# Altium schematic sheet MARK1_EDU_PMOD.SchDoc
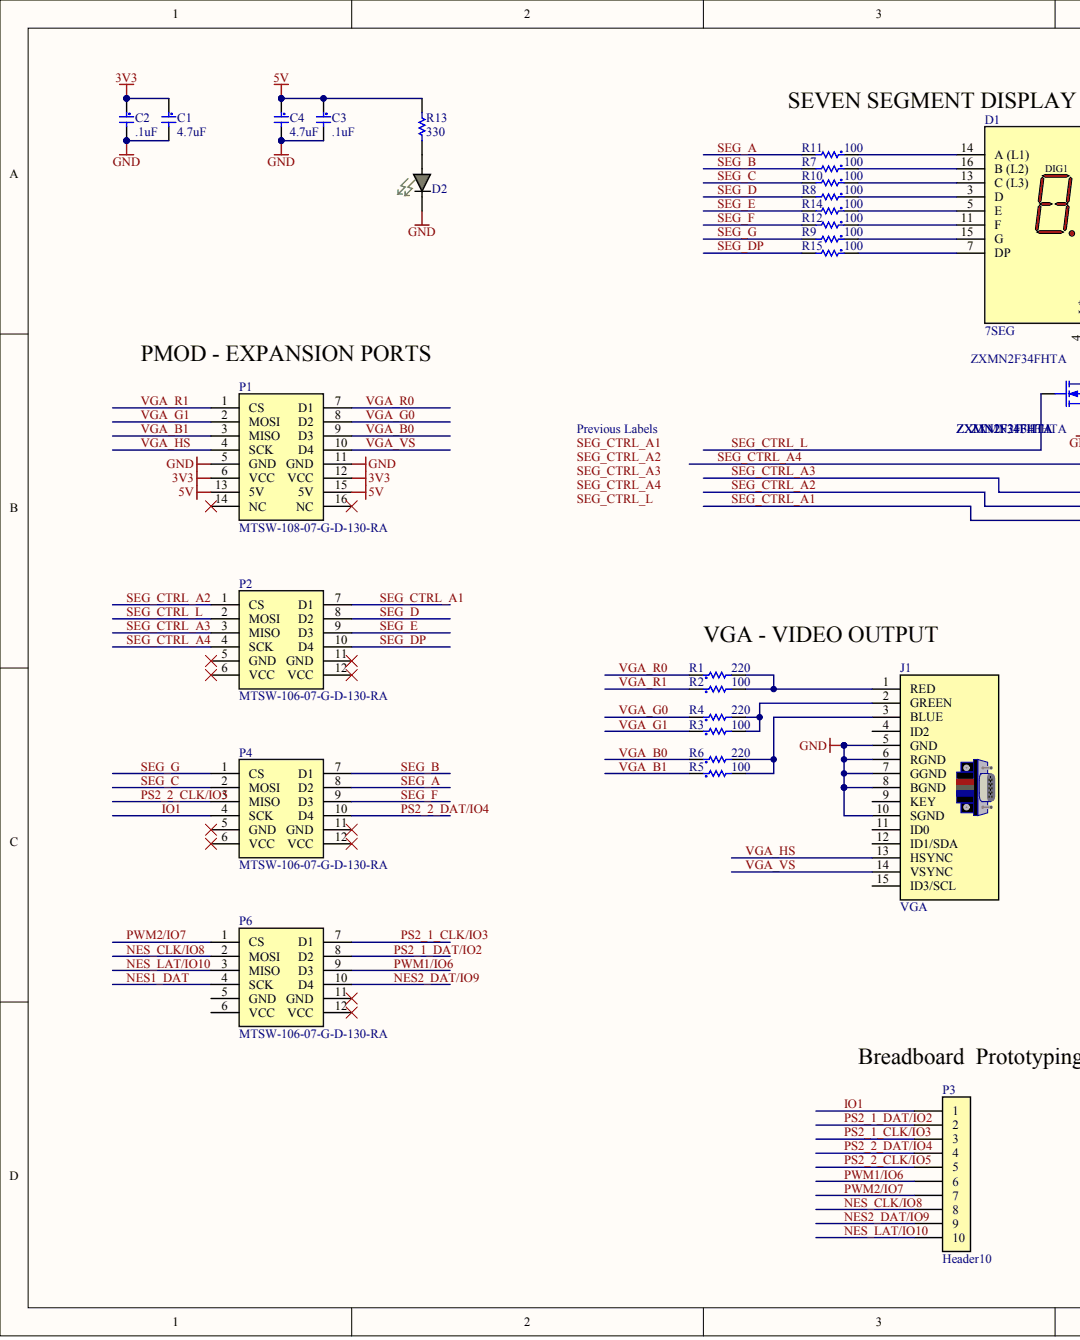
[diagram p0: MARK1_EDU_PMOD.SchDoc, left]
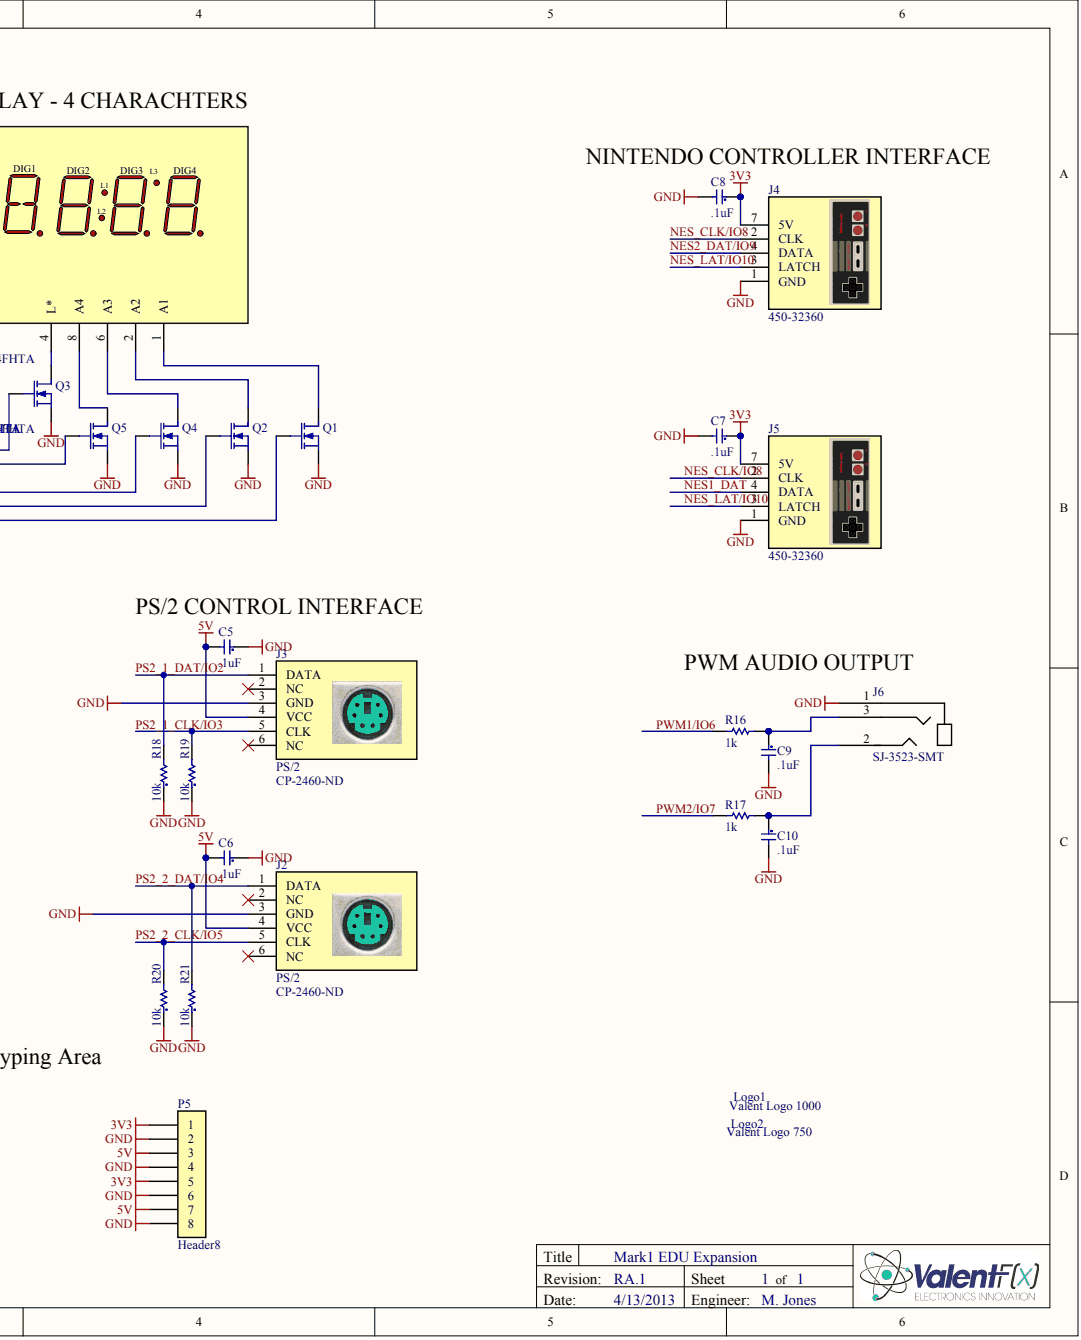
[diagram p1: MARK1_EDU_PMOD.SchDoc, right]

## Parts
C1  4.7uF
C2  .1uF
C3  .1uF
C4  4.7uF
C5  .1uF
C6  .1uF
C7  .1uF
C8  .1uF
C9  .1uF
C10  .1uF
D1  7SEG
D2  LED 1.6X0.8MM 570NM GRN CLR SMD  (value not drawn)
J1  VGA
J2  Supplier Part Number 1: CP-2460-ND  (hidden comment: PS/2)
J3  Supplier Part Number 1: CP-2460-ND  (hidden comment: PS/2)
J4  450-32360
J5  450-32360
J6  SJ-3523-SMT
Logo1
Logo2
P1  MTSW-108-07-G-D-130-RA
P2  MTSW-106-07-G-D-130-RA
P3  Header10
P4  MTSW-106-07-G-D-130-RA
P5  Header8
P6  MTSW-106-07-G-D-130-RA
Q1  ZXMN2F34FHTA  (value not drawn)
Q2  ZXMN2F34FHTA  (value not drawn)
Q3  ZXMN2F34FHTA
Q4  ZXMN2F34FHTA  (value not drawn)
Q5  ZXMN2F34FHTA
R1  220
R2  100
R3  100
R4  220
R5  100
R6  220
R7  100
R8  100
R9  100
R10  100
R11  100
R12  100
R13  330
R14  100
R15  100
R16  1k
R17  1k
R18  10k
R19  10k
R20  10k
R21  10k

## Nets
3V3: C1.1 C2.1 C7.1 C8.1 J4.7(5V) J5.7(5V) P1.6(VCC) P1.12(VCC) P5.1 P5.5
5V: C3.1 C4.1 C5.2 C6.2 J2.4(VCC) J3.4(VCC) P1.13(5V) P1.15(5V) P5.3 P5.7 R13.1
GND: C1.2 C2.2 C3.2 C4.2 C5.1 C6.1 C7.2 C8.2 C9.2 C10.2 D2.C J1.5(GND) J1.6(RGND) J1.7(GGND) J1.8(BGND) J1.10(SGND) J2.3(GND) J3.3(GND) J4.1(GND) J5.1(GND) J6.1 P1.5(GND) P1.11(GND) P5.2 P5.4 P5.6 P5.8 Q1.2 Q2.2 Q3.2 Q4.2 Q5.2 R18.1 R19.1 R20.1 R21.1
IO1: P3.1 P4.4(SCK)
NES1_DAT: J5.4(DATA) P6.4(SCK)
NES2_DAT/IO9: J4.4(DATA) P3.9 P6.10(D4)
NES_CLK/IO8: J4.2(CLK) J5.2(CLK) P3.8 P6.2(MOSI)
NES_LAT/IO10: J4.3(LATCH) J5.3(LATCH) P3.10 P6.3(MISO)
PS2_1_CLK/IO3: J3.5(CLK) P3.3 P6.7(D1) R19.2
PS2_1_DAT/IO2: J3.1(DATA) P3.2 P6.8(D2) R18.2
PS2_2_CLK/IO5: J2.5(CLK) P3.5 P4.3(MISO) R20.2
PS2_2_DAT/IO4: J2.1(DATA) P3.4 P4.10(D4) R21.2
PWM1/IO6: P3.6 P6.9(D3) R16.1
PWM2/IO7: P3.7 P6.1(CS) R17.1
SEG_A: P4.8(D2) R11.2
SEG_B: P4.7(D1) R7.2
SEG_C: P4.2(MOSI) R10.2
SEG_CTRL_A1: P2.7(D1) Q1.1
SEG_CTRL_A2: P2.1(CS) Q2.1
SEG_CTRL_A3: P2.3(MISO) Q4.1
SEG_CTRL_A4: P2.4(SCK) Q5.1
SEG_CTRL_L: P2.2(MOSI) Q3.1
SEG_D: P2.8(D2) R8.2
SEG_DP: P2.10(D4) R15.2
SEG_E: P2.9(D3) R14.2
SEG_F: P4.9(D3) R12.2
SEG_G: P4.1(CS) R9.2
VGA_B0: P1.9(D3) R6.1
VGA_B1: P1.3(MISO) R5.1
VGA_G0: P1.8(D2) R4.1
VGA_G1: P1.2(MOSI) R3.1
VGA_HS: J1.13(HSYNC) P1.4(SCK)
VGA_R0: P1.7(D1) R1.1
VGA_R1: P1.1(CS) R2.1
VGA_VS: J1.14(VSYNC) P1.10(D4)
NetC9_1: C9.1 J6.3 R16.2
NetC10_1: C10.1 J6.2 R17.2
NetD1_1: D1.1(A1) Q1.3
NetD1_2: D1.2(A2) Q2.3
NetD1_3: D1.3(D) R8.1
NetD1_4: D1.4(L*) Q3.3
NetD1_5: D1.5(E) R14.1
NetD1_6: D1.6(A3) Q4.3
NetD1_7: D1.7(DP) R15.1
NetD1_8: D1.8(A4) Q5.3
NetD1_11: D1.11(F) R12.1
NetD1_13: D1.13(C_(L3)) R10.1
NetD1_14: D1.14(A_(L1)) R11.1
NetD1_15: D1.15(G) R9.1
NetD1_16: D1.16(B_(L2)) R7.1
NetD2_A: D2.A R13.2
NetJ1_1: J1.1(RED) R1.2 R2.2
NetJ1_2: J1.2(GREEN) R3.2 R4.2
NetJ1_3: J1.3(BLUE) R5.2 R6.2

Not connected: J1 4(ID2) 9(KEY) 11(ID0) 12(ID1/SDA) 15(ID3/SCL); J2 2(NC) 6(NC); J3 2(NC) 6(NC); P1 14(NC) 16(NC); P2 5(GND) 6(VCC) 11(GND) 12(VCC); P4 5(GND) 6(VCC) 11(GND) 12(VCC); P6 5(GND) 6(VCC) 11(GND) 12(VCC)

## Library data of the parts
C1 C4 = (no symbol name): Manufacturer: Murata; Manufacturer Part Number: GRM188F51A475ZE20D; Tolerance: - 20 %, + 80 %; Voltage Rating: 10 Volts; Temperature Coefficient / Code: Y5V; Supplier 1: Mouser; RoHS: RoHS Compliant By Exemption; Category: Multilayer Ceramic Capacitors (MLCC) - SMD/SMT; Stock 1: 32662; Pricing 1: 1=0.257, 50=0.159, 100=0.123, 500=0.065, 1000=0.046, 4000=0.032, 8000=0.028, 12000=0.024 (AUD); Capacitance: 4.7 uF; Dimensions: 0.8 mm W x 1.6 mm L x 0.8 mm H; Operating Temperature Range: - 30 C to + 85 C; Package / Case: 0603 (1608 metric); Packaging: Reel; Product: General Type MLCCs; Series: GRM; Termination Style: SMD/SMT; Product Category: High Capacitance SMD Ceramic Chip Capacitors; Standard Pack Qty: 4000; RoHS - Mouser: E; Minimum Order Quantity: 1; Reeling: True; ComponentLink1URL: http://www.murata.com/products/catalog/pdf/c02e.pdf; ComponentLink1Description: http://www.murata.com/products/catalog/pdf/c02e.pdf; Supplier Part Number 1: 81-GRM188F51A475ZE20; Value: 4.7uF
C2 C3 C5-C10 = (no symbol name): Manufacturer: Murata; Manufacturer Part Number: GRM188R71H104KA93D; Capacitance: 0.1 uF; Tolerance: 10 %; Voltage Rating: 50 Volts; Temperature Coefficient / Code: X7R; Supplier 1: Mouser; RoHS: RoHS Compliant By Exemption; Category: Multilayer Ceramic Capacitors (MLCC) - SMD/SMT; Stock 1: 327594; Pricing 1: 1=0.081, 50=0.054, 100=0.041, 500=0.018, 1000=0.015, 4000=0.012 (AUD); Dimensions: 0.8 mm W x 1.6 mm L x 0.8 mm H; Operating Temperature Range: - 55 C to + 125 C; Package / Case: 0603 (1608 metric); Packaging: Reel; Product: General Type MLCCs; Series: GRM; Termination Style: SMD/SMT; Product Category: Low & Medium Capacitance SMD Ceramic Chip Capacitors; Standard Pack Qty: 4000; RoHS - Mouser: E; Minimum Order Quantity: 1; Reeling: True; ComponentLink1URL: http://www.murata.com/products/catalog/pdf/c02e.pdf; ComponentLink1Description: http://www.murata.com/products/catalog/pdf/c02e.pdf; Supplier Part Number 1: 81-GRM39X104K50D; Value: .1uF
D1 = (no symbol name): Manufacturer: Lite-On; Manufacturer Part Number: LTC-4727JR; Character Size: 10 mm; Display Type: 7 Segment; Illumination Color: Red; Operating Voltage: 2 V; Power Consumption: 70 mW; Wavelength: 631 nm; Common Pin: Common Cathode; Luminous Intensity: 975 ucd; Operating Current: 25 mA; Number of Digits: 4; Supplier 1: Mouser; RoHS: RoHS Compliant; Category: LED Displays & Accessories; Stock 1: 1398; Pricing 1: 1=2.88, 10=2.74, 50=2.67, 100=2.61, 500=2.31 (AUD); Packaging: Tube; Maximum Operating Temperature: + 85 C; Minimum Operating Temperature: - 35 C; Product Category: Numeric Display; Standard Pack Qty: 12; RoHS - Mouser: Y; Minimum Order Quantity: 1; Reeling: False; ComponentLink1URL: http://optoelectronics.liteon.com/en-us/product/detail.aspx?txtSpecNo=DS30-2000-193&txtPartNo=LTC-4727JR; ComponentLink1Description: http://optoelectronics.liteon.com/en-us/product/detail.aspx?txtSpecNo=DS30-2000-193&txtPartNo=LTC-4727JR
D2 = (no symbol name): Manufacturer: Kingbright Corp; Manufacturer Part Number: APT1608CGCK; Color: Green; Current - Test: 20mA; Wavelength - Peak: 574nm; Millicandela Rating: 50mcd; Voltage - Forward (Vf) Typ: 2.1V; Lens Type: Clear; Lens Style/Size: Rectangle, 1.2mm x 0.8mm; Viewing Angle: 120°; Value: LED 1.6X0.8MM 570NM GRN CLR SMD; Supplier 1: Digi-Key; Supplier Part Number 1: 754-1116-2-ND; RoHS: RoHS Compliant; Category: Optoelectronics; Stock 1: 94000; Pricing 1: 2000=0.051, 6000=0.045, 10000=0.043 (USD); Luminous Flux @ Current - Test: -; Wavelength - Dominant: 570nm; Size / Dimension: 1.60mm L x 0.80mm W; Height: 0.75mm; Package / Case: 0603 (1608 Metric); Mounting Type: Surface Mount; Packaging: Tape & Reel (TR); ComponentLink1URL: http://www.us.kingbright.com/images/catalog/SPEC/APT1608CGCK.pdf; ComponentLink1Description: http://www.us.kingbright.com/images/catalog/SPEC/APT1608CGCK.pdf
J1 = (no symbol name): Manufacturer: TE Connectivity; Manufacturer Part Number: 1-1734530-1; Connector Style: D-Sub, High Density; Number of Positions: 15; Connector Type: Receptacle, Female Sockets; Flange Feature: Housing/Shell (4-40); Voltage Rating: 125V; Current Rating: 2A; Supplier 1: Digi-Key; RoHS: RoHS Compliant; Category: Connectors, Interconnects; Stock 1: 6957; Pricing 1: 1=1.96, 10=1.779, 25=1.67, 50=1.5976, 100=1.525, 250=1.37972, 500=1.2708, 1000=1.08926, 2500=1.01664 (USD); Number of Rows: 3; Shell Size, Connector Layout: 1 (DE, E) High Density; Contact Type: Signal; Mounting Type: Through Hole, Right Angle; Termination: Solder; Features: Board Lock; Shell Material, Finish: Steel, Nickel Plated; Contact Finish: Gold; Contact Finish Thickness: Flash; Ingress Protection: -; Operating Temperature: -55°C ~ 105°C; Housing Material: Thermoplastic; Color: Black; ComponentLink1URL: http://documents.tycoelectronics.com/commerce/DocumentDelivery/DDEController?Action=srchrtrv&DocNm=1734530&DocType=CD&DocLang=EN; ComponentLink1Description: http://documents.tycoelectronics.com/commerce/DocumentDelivery/DDEController?Action=srchrtrv&DocNm=1734530&DocType=CD&DocLang=EN
J2 J3 = (no symbol name): Manufacturer: CUI Inc; Manufacturer Part Number: MD-60S; Connector Type: Receptacle, Female Sockets; Number of Positions: 6; Current Rating: 2A; Voltage - Rated: 12VDC; Supplier 1: Digi-Key; RoHS: RoHS Compliant; Category: Connectors, Interconnects; Stock 1: 13581; Pricing 1: 1=1.31, 10=1.018, 100=0.7763, 500=0.621, 1000=0.552, 2500=0.45885 (USD); Shell Size - Insert: Mini DIN; Shell Size, MIL: -; Mounting Type: Through Hole, Right Angle; Termination: Solder; Fastening Type: Push-Pull; Orientation: Keyed; Ingress Protection: -; Shell Material, Finish: Polybutylene Terephthalate (PBT); Contact Finish: Silver; Features: -; Packaging: Bulk; Contact Finish Thickness: -; Operating Temperature: -; ComponentLink1URL: http://products.cui.com/GetSpecForDigiKey.aspx?MFGNum=MD-60S; ComponentLink1Description: http://products.cui.com/GetSpecForDigiKey.aspx?MFGNum=MD-60S; Value: PS/2
J4 J5 = (no symbol name): Manufacturer: Parallax Inc; Accessory Type: Adapter NES Controller Socket; For Use With/Related Products: Game Systems, Development, Hobby and Education Projects; Supplier 1: Digi-Key; Manufacturer Part Number: 450-32360; RoHS: RoHS Compliant; Category: Programmers, Development Systems; Stock 1: 7; Pricing 1: 1=1.99 (USD); Supplier 2: Mouser; Stock 2: 0; Pricing 2: 1=1.85 (USD); Product Category: Basic Stamp Accessories; RoHS - Mouser: Y; Minimum Order Quantity: 1; Reeling: False; Supplier Part Number 1: 450-32360-ND; Supplier Part Number 2: 619-450-32360; Value: 450-32360
J6 = (no symbol name): Manufacturer: CUI Inc; Source Part Number: CP-3523SJCT-ND; Connector Type: Phone Jack; Gender: Female; Signal Lines: Stereo; Plug/Mating Plug Diameter: 3.50mm (0.141", 1/8", Mini Plug) - Headphone; Number of Positions/Contacts: 3 Conductors, 3 Contacts; Internal Switch(s): Does Not Contain Switch; Shielding: Unshielded; Color - Contact: Black; Voltage - Rated: 12VDC; Current Rating: 1A; Manufacturer Part Number: SJ-3523-SMT; ROHS Certificate: ROHS-CUI.pdf; Supplier 1: Digi-Key; Supplier Part Number 1: CP-3523SJCT-ND; RoHS: RoHS Compliant; Category: Connectors, Interconnects; Stock 1: 68095; Pricing 1: 1=1.34, 10=1.043, 100=0.7961, 500=0.63558 (USD); Mounting Type: Surface Mount, Right Angle; Termination: Solder; Features: -; Contact Material: Copper Alloy; Contact Material - Plating: Silver; Housing Material: Plastic; Housing Color: Black; Operating Temperature: -25°C ~ 85°C; Packaging: Cut Tape (CT); ComponentLink1URL: http://products.cui.com/GetSpecForDigiKey.aspx?MFGNum=SJ-3523-SMT; ComponentLink1Description: http://products.cui.com/GetSpecForDigiKey.aspx?MFGNum=SJ-3523-SMT; Value: SJ-3523-SMT; Source: Digikey
P1 P2 P4 P6 = (no symbol name): Manufacturer: Samtec; Lead Time: 0 weeks; Peak Solder Temperature: 260 deg C; MSL (Moisture Sensitivity Level): N/R; Supplier 1: Arrow; RoHS: CHINA ROHS: Compliant / EU ROHS: Compliant; Stock 1: 0; Termination Finish: Au/Ni; China ROHS Lead (X -> above / O -> below threshold): O; China ROHS Mercury (X -> above / O -> below threshold): O; China ROHS Cadmium (X -> above / O -> below threshold): O; China ROHS Hex Chromium (X -> above / O -> below threshold): O; China ROHS PBB (X -> above / O -> below threshold): O; China ROHS PBDE (X -> above / O -> below threshold): O; EU_ROHS_PROD_EXEMPTION_BY_SUPP: N/R; ComponentLink1URL: http://components.arrow.com/partservice/dataview/altium/2717179?apiKey=aa438727d59eed98c4bed826d8d949c84cb5e685b92bf87346a0226bd2658797; ComponentLink1Description: http://components.arrow.com/partservice/dataview/altium/2717179?apiKey=aa438727d59eed98c4bed826d8d949c84cb5e685b92bf87346a0226bd2658797; Supplier Part Number 1: 2717179
  P1: Manufacturer Part Number: MTSW-108-07-G-D-130-RA; =Value: MTSW-108-07-G-D-130-RA; Value: MTSW-108-07-G-D-130-RA
  P2 P4 P6: Manufacturer Part Number: MTSW-106-07-G-D-130-RA; =Value: MTSW-106-07-G-D-130-RA; Value: MTSW-106-07-G-D-130-RA
Q1-Q5 = (no symbol name): Manufacturer: Diodes/Zetex; FET Type: MOSFET N-Channel, Metal Oxide; FET Feature: Logic Level Gate; Rds On (Max) @ Id, Vgs: 60 mOhm @ 2.5A, 4.5V; Drain to Source Voltage (Vdss): 20V; Current - Continuous Drain (Id) @ 25° C: 3.4A; Vgs(th) (Max) @ Id: 1.5V @ 250µA; Gate Charge (Qg) @ Vgs: 2.8nC @ 4.5V; Input Capacitance (Ciss) @ Vds: 277pF @ 10V; Power - Max: 950mW; Supplier 1: Digi-Key; Manufacturer Part Number: ZXMN2F34FHTA; RoHS: RoHS Compliant; Category: Discrete Semiconductor Products; Stock 1: 24122; Pricing 1: 1=0.55, 10=0.433, 100=0.2964, 250=0.247, 500=0.2052, 1000=0.152 (USD); Mounting Type: Surface Mount; Package / Case: SOT-23-3, TO-236-3, Micro3Ž, SSD3, SST3; Packaging: Cut Tape (CT); Supplier Device Package: *; ComponentLink1URL: http://www.diodes.com/datasheets/ZXMN2F34FH.pdf; ComponentLink1Description: http://www.diodes.com/datasheets/ZXMN2F34FH.pdf; Supplier Part Number 1: ZXMN2F34FHCT-ND; Value: ZXMN2F34FHTA
R1 R4 R6 = (no symbol name): Manufacturer: Panasonic - ECG; Manufacturer Part Number: ERJ-3EKF2200V; Power (Watts): 0.1W, 1/10W; Temperature Coefficient: ±100ppm/°C; Tolerance: ±1%; Supplier 1: Digi-Key; RoHS: RoHS Compliant; Category: Resistors; Stock 1: 517798; Pricing 1: 1=0.1, 50=0.0138, 100=0.0105, 250=0.0078, 500=0.00576, 1000=0.00384 (USD); Resistance (Ohms): 220; Composition: Thick Film; Features: -; Package / Case: 0603 (1608 Metric); Size / Dimension: 0.063" L x 0.031" W (1.60mm x 0.80mm); Height: 0.022" (0.55mm); Number of Terminations: 2; Packaging: Cut Tape (CT); ComponentLink1URL: http://industrial.panasonic.com/www-cgi/jvcr13pz.cgi?E+PZ+3+AOA0002+ERJ3EKF2200V+7+WW; ComponentLink1Description: http://industrial.panasonic.com/www-cgi/jvcr13pz.cgi?E+PZ+3+AOA0002+ERJ3EKF2200V+7+WW; Supplier Part Number 1: P220HCT-ND; Value: 220
R2 R3 R5 = (no symbol name): Manufacturer: Vishay/Dale; Manufacturer Part Number: CRCW0603100RFKEA; Power Rating: 0.1 Watt (1/10 Watt); Resistance: 100 Ohms; Temperature Coefficient: 100 PPM / K; Tolerance: 1 %; Voltage Rating: 75 Volts; Supplier 1: Mouser; RoHS: RoHS Compliant By Exemption; Category: Thick Film Resistors - SMD; Stock 1: 243353; Pricing 1: 1=0.108, 25=0.054, 100=0.034, 1000=0.020, 2000=0.018, 5000=0.008, 10000=0.007, 50000=0.005 (AUD); Dimensions: 0.85 mm W x 1.55 mm L x 0.45 mm H; Operating Temperature Range: - 55 C to + 155 C; Package / Case: 0603 (1608 metric); Packaging: Reel; Product: Thick Film Resistors SMD; Series: CRCW; Termination Style: SMD/SMT; Product Category: 0603 Thick Film Chip Resistors; Standard Pack Qty: 5000; RoHS - Mouser: E; Minimum Order Quantity: 1; Reeling: True; ComponentLink1URL: http://www.vishay.com/doc?20035; ComponentLink1Description: http://www.vishay.com/doc?20035; Supplier Part Number 1: 71-CRCW0603-100-E3; Value: 100
R7-R12 R14 R15 = (no symbol name): Manufacturer: Panasonic - ECG; Manufacturer Part Number: ERJ-3EKF1002V; Resistance (Ohms): 10.0K; Power (Watts): 0.1W, 1/10W; Temperature Coefficient: ±100ppm/°C; Tolerance: ±1%; Value: 100; Supplier 1: Digi-Key; RoHS: RoHS Compliant; Category: Resistors; Stock 1: 11168880; Pricing 1: 1=0.04, 50=0.015, 100=0.0114, 250=0.00844, 500=0.00624, 1000=0.00416 (USD); Composition: Thick Film; Features: -; Size / Dimension: 0.063" L x 0.031" W (1.60mm x 0.80mm); Height: 0.018" (0.45mm); Lead Style: Surface Mount (SMD - SMT); Packaging: Cut Tape (CT); Package / Case: 0603 (1608 Metric); ComponentLink1URL: http://industrial.panasonic.com/www-cgi/jvcr13pz.cgi?E+PZ+3+AOA0002+ERJ3EKF1002V+7+WW; ComponentLink1Description: http://industrial.panasonic.com/www-cgi/jvcr13pz.cgi?E+PZ+3+AOA0002+ERJ3EKF1002V+7+WW; Supplier Part Number 1: P10.0KHCT-ND
R13 = (no symbol name): Manufacturer: Panasonic - ECG; Manufacturer Part Number: ERJ-3EKF3300V; Value: RES 330 OHM 1/10W 1% 0603 SMD; Power (Watts): 0.1W, 1/10W; Temperature Coefficient: ±100ppm/°C; Tolerance: ±1%; Supplier 1: Digi-Key; Supplier Part Number 1: P330HCT-ND; RoHS: RoHS Compliant; Category: Resistors; Stock 1: 592917; Pricing 1: 1=0.04, 50=0.015, 100=0.0114, 250=0.00844, 500=0.00624, 1000=0.00416 (USD); Resistance (Ohms): 330; Composition: Thick Film; Features: -; Size / Dimension: 0.063" L x 0.031" W (1.60mm x 0.80mm); Height: 0.018" (0.45mm); Lead Style: Surface Mount (SMD - SMT); Packaging: Cut Tape (CT); Package / Case: 0603 (1608 Metric); ComponentLink1URL: http://industrial.panasonic.com/www-cgi/jvcr13pz.cgi?E+PZ+3+AOA0002+ERJ3EKF3300V+7+WW; ComponentLink1Description: http://industrial.panasonic.com/www-cgi/jvcr13pz.cgi?E+PZ+3+AOA0002+ERJ3EKF3300V+7+WW
R16 R17 = (no symbol name): Manufacturer: Panasonic - ECG; Manufacturer Part Number: ERJ-3EKF1001V; Resistance (Ohms): 1.00K; Power (Watts): 0.1W, 1/10W; Temperature Coefficient: ±100ppm/°C; Tolerance: ±1%; Supplier 1: Digi-Key; RoHS: RoHS Compliant; Category: Resistors; Stock 1: 7422563; Pricing 1: 1=0.04, 50=0.015, 100=0.0114, 250=0.00844, 500=0.00624, 1000=0.00416 (USD); Composition: Thick Film; Features: -; Size / Dimension: 0.063" L x 0.031" W (1.60mm x 0.80mm); Height: 0.018" (0.45mm); Lead Style: Surface Mount (SMD - SMT); Packaging: Cut Tape (CT); Package / Case: 0603 (1608 Metric); ComponentLink1URL: http://industrial.panasonic.com/www-cgi/jvcr13pz.cgi?E+PZ+3+AOA0002+ERJ3EKF1001V+7+WW; ComponentLink1Description: http://industrial.panasonic.com/www-cgi/jvcr13pz.cgi?E+PZ+3+AOA0002+ERJ3EKF1001V+7+WW; Value: 1k; Supplier Part Number 1: P1.00KHCT-ND
R18-R21 = (no symbol name): Manufacturer: Vishay Dale; Manufacturer Part Number: CRCW060310K0FKEA; Power (Watts): 0.1W, 1/10W; Temperature Coefficient: ±100ppm/°C; Tolerance: ±1%; Supplier 1: Digi-Key; RoHS: RoHS Compliant; Category: Resistors; Stock 1: 997856; Pricing 1: 10=0.081, 50=0.0436, 200=0.0251, 1000=0.01711 (USD); Resistance (Ohms): 10K; Composition: Thick Film; Features: -; Package / Case: 0603 (1608 Metric); Size / Dimension: 0.061" L x 0.033" W (1.55mm x 0.85mm); Height: 0.020" (0.50mm); Number of Terminations: 2; Packaging: Cut Tape (CT); ComponentLink1URL: http://www.vishay.com/doc?20035; ComponentLink1Description: http://www.vishay.com/doc?20035; Supplier Part Number 1: 541-10.0KHCT-ND; Value: 10k
